# Altium schematic sheet BrewTempController.SchDoc
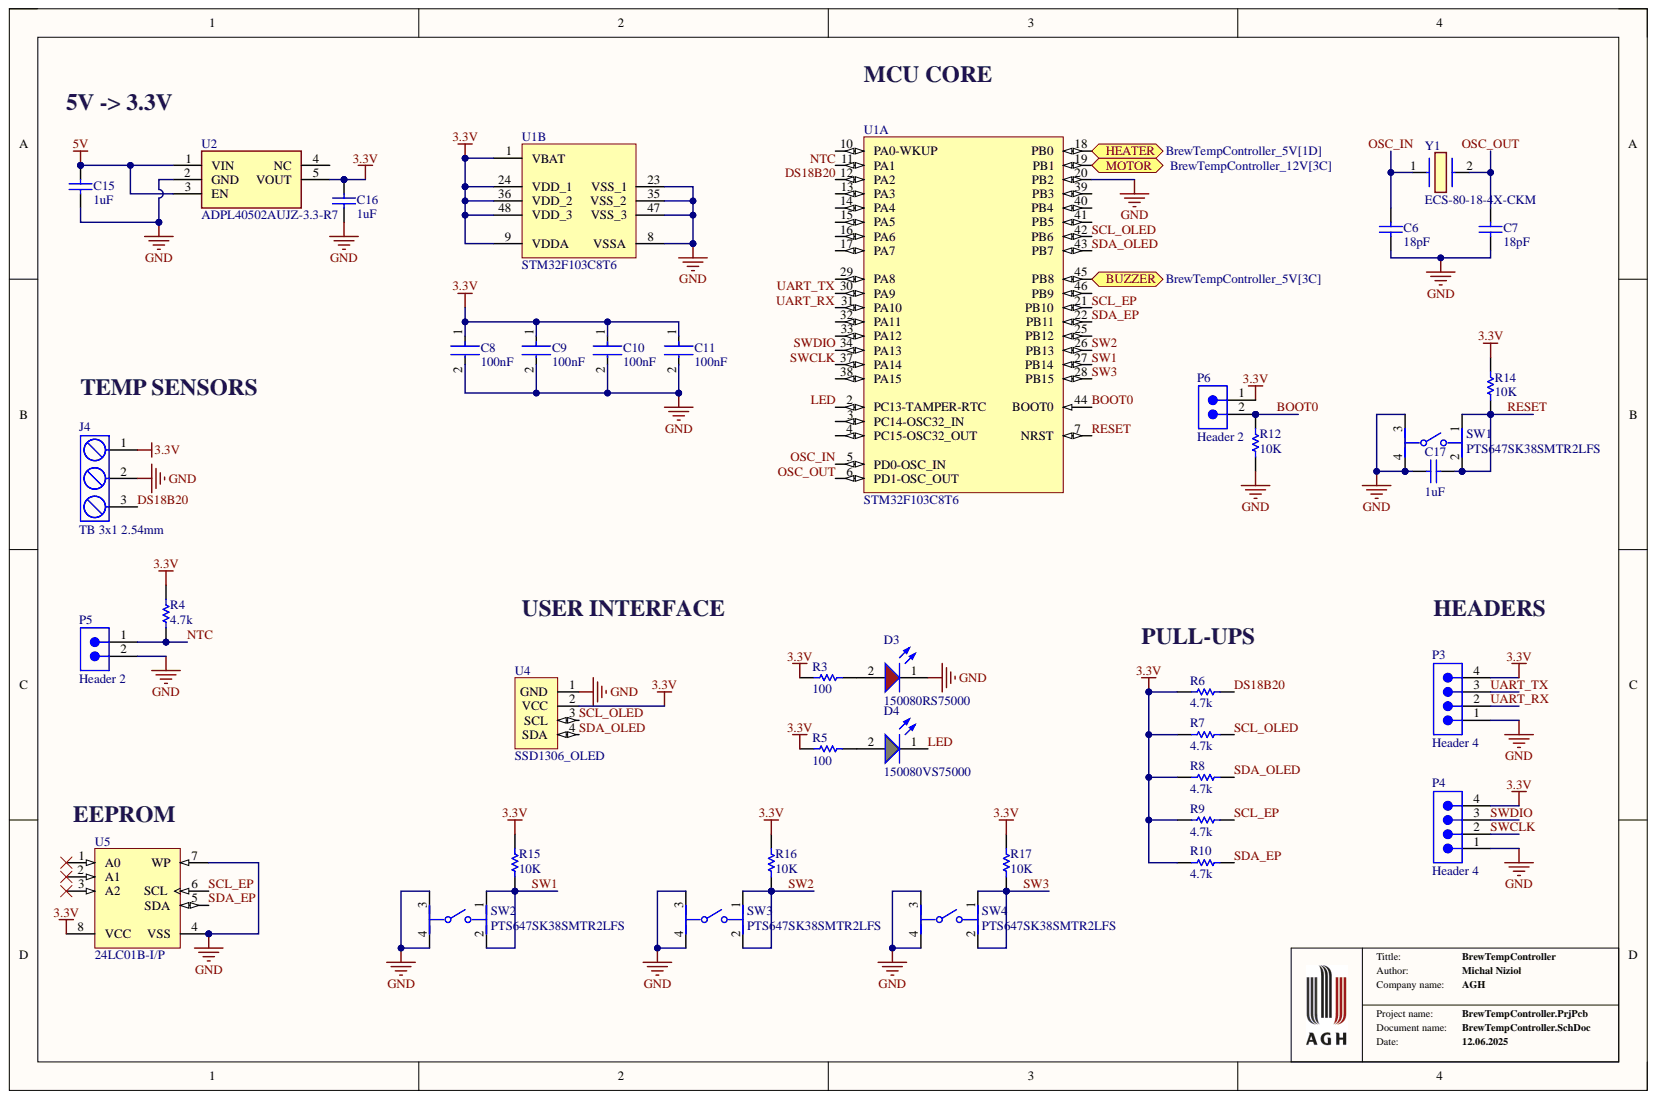
[diagram p0: BrewTempController.SchDoc]

## Parts
C6  18pF  [CAPC0805(2012)75_L]
C7  18pF  [CAPC0805(2012)75_L]
C8  100nF  [FP-0805-L_2_01_0_2-W_1_25-MFG]
C9  100nF  [FP-0805-L_2_01_0_2-W_1_25-MFG]
C10  100nF  [FP-0805-L_2_01_0_2-W_1_25-MFG]
C11  100nF  [FP-0805-L_2_01_0_2-W_1_25-MFG]
C15  1uF  [CAPC2013X140X50ML20T25]
C16  1uF  [CAPC2013X140X50ML20T25]
C17  1uF  [CAPC2013X140X50ML20T25]
D3  150080RS75000  [WL-SMCW_0805_150080xx75000]
D4  150080VS75000  [WL-SMCW_0805_150080xx75000]
J4  TB 3x1 2.54mm  [TB_3x1_2.54mm]
P3  Header 4  [61300411121]
P4  Header 4  [61300411121]
P5  Header 2  [SMTC-TSW-102-26-XX-S]
P6  Header 2  [SMTC-TSW-102-26-XX-S]
R3  100  [RESC2013X60X30LL20T20]
R4  4.7k  [RESC2013X60X30LL20T20]
R5  100  [RESC2013X60X30LL20T20]
R6  4.7k  [RESC2013X60X30LL20T20]
R7  4.7k  [RESC2013X60X30LL20T20]
R8  4.7k  [RESC2013X60X30LL20T20]
R9  4.7k  [RESC2013X60X30LL20T20]
R10  4.7k  [RESC2013X60X30LL20T20]
R12  10K  [RESC2013X60X30NL20T20]
R14  10K  [RESC2013X60X30NL20T20]
R15  10K  [RESC2013X60X30NL20T20]
R16  10K  [RESC2013X60X30NL20T20]
R17  10K  [RESC2013X60X30NL20T20]
SW1  PTS647SK38SMTR2LFS  [FP-PTS647SK38SMTR2LFS-MFG]
SW2  PTS647SK38SMTR2LFS  [FP-PTS647SK38SMTR2LFS-MFG]
SW3  PTS647SK38SMTR2LFS  [FP-PTS647SK38SMTR2LFS-MFG]
SW4  PTS647SK38SMTR2LFS  [FP-PTS647SK38SMTR2LFS-MFG]
U1  STM32F103C8T6  [STM-LQFP48_L]  (parts A,B)
U2  ADPL40502AUJZ-3.3-R7  [SOT95P280X100-5N]
U4  SSD1306_OLED  [SSD1306]
U5  24LC01B-I/P  [PDIP300-P8]
Y1  ECS-80-18-4X-CKM  [ECS80184XCKM]

## Ports
BUZZER, HEATER, MOTOR

## Nets
3.3V: C8.1 C9.1 C10.1 C11.1 C16.2 J4.1 P3.4 P4.4 P6.1 R3.1 R4.2 R5.1 R6.1 R7.1 R8.1 R9.1 R10.1 R14.2 R15.2 R16.2 R17.2 U1.1(VBAT) U1.9(VDDA) U1.24(VDD_1) U1.36(VDD_2) U1.48(VDD_3) U2.5(VOUT) U4.2(VCC) U5.8(VCC)
5V: C15.2 U2.1(VIN) U2.3(EN)
GND: C6.1 C7.1 C8.2 C9.2 C10.2 C11.2 C15.1 C16.1 C17.1 D3.1 J4.2 P3.1 P4.1 P5.2 R12.1 SW1.3 SW1.4 SW2.3 SW2.4 SW3.3 SW3.4 SW4.3 SW4.4 U1.8(VSSA) U1.20(PB2) U1.23(VSS_1) U1.35(VSS_2) U1.47(VSS_3) U2.2(GND) U4.1(GND) U5.4(VSS) U5.7(WP)
BOOT0: P6.2 R12.2 U1.44(BOOT0)
BUZZER: U1.45(PB8) ; port BUZZER
DS18B20: J4.3 R6.2 U1.12(PA2)
HEATER: U1.18(PB0) ; port HEATER
LED: D4.1 U1.2(PC13-TAMPER-RTC)
MOTOR: U1.19(PB1) ; port MOTOR
NTC: P5.1 R4.1 U1.11(PA1)
OSC_IN: C6.2 U1.5(PD0-OSC_IN) Y1.1
OSC_OUT: C7.2 U1.6(PD1-OSC_OUT) Y1.2
RESET: C17.2 R14.1 SW1.1 SW1.2 U1.7(NRST)
SCL_EP: R9.2 U1.21(PB10) U5.6(SCL)
SCL_OLED: R7.2 U1.42(PB6) U4.3(SCL)
SDA_EP: R10.2 U1.22(PB11) U5.5(SDA)
SDA_OLED: R8.2 U1.43(PB7) U4.4(SDA)
SW1: R15.1 SW2.1 SW2.2 U1.27(PB14)
SW2: R16.1 SW3.1 SW3.2 U1.26(PB13)
SW3: R17.1 SW4.1 SW4.2 U1.28(PB15)
SWCLK: P4.2 U1.37(PA14)
SWDIO: P4.3 U1.34(PA13)
UART_RX: P3.2 U1.31(PA10)
UART_TX: P3.3 U1.30(PA9)
NetD3_2: D3.2 R3.2
NetD4_2: D4.2 R5.2

Not connected: U1 3(PC14-OSC32_IN) 4(PC15-OSC32_OUT) 10(PA0-WKUP) 13(PA3) 14(PA4) 15(PA5) 16(PA6) 17(PA7) 25(PB12) 29(PA8) 32(PA11) 33(PA12) 38(PA15) 39(PB3) 40(PB4) 41(PB5) 46(PB9); U2 4(NC); U5 1(A0) 2(A1) 3(A2)

## Library data of the parts
C6 C7 = CMP-1036-01120-1: CAP 18pF 16V ±5% 0805 (2012 Metric) Thickness 0.75mm SMD; Case-EIA: 0805; Case-Metric: 2012; Tolerance: Â±5%; Component Kind: Standard; Library Name: Altium Content Vault; Max Thickness: 0.75 mm; Rated Voltage: 16 V; Technology: SMT; Value: 18pF
C8-C11 = CMP-2007-04725-2: Automotive Ceramic Capacitor, 0805, 100nF, 5%, X7R, 15%, 50V; Dissipation Factor: 2.5%; Capacitance: 100nF; Case Code (Imperial): 0805; Case Code (Metric): 2012; Case/Package: 0805; Component Kind: Standard; Construction: Flat; Contact Plating: Tin; Depth: 1.25mm; Dielectric: X7R; Height: 0.94mm; Lead Free: Lead Free; Length: 2.01mm; Library Name: Altium Content Vault; Max Operating Temperature: 125°C; Min Operating Temperature: -55°C; Mount: Surface Mount; Number of Pins: 2; Package Quantity: 4000; Packaging: Tape and Reel; Radiation Hardening: No; Ratings: AEC-Q200; RoHS Compliant: Yes; Series: -; Termination: SMD/SMT; Thickness: 0.037inch; Tolerance: 5%; Voltage Rating: 50V; Voltage Rating (DC): 50V; Width: 0.049inch
C15-C17 = CMP-1036-04744-2: Manufacturer: AVX Interconnect / Elco; Part Number: 0805YC105JAT2A; Component Kind: Standard; Library Name: Altium Content Vault
D3 = CMP-1426-00011-3: LED Uni-Color Red 630nm 2-Pin Chip 0805(2012Metric) T/R; Dominant Wavelength: 625nm; Forward Current: 100mA; Forward Voltage: 2V; Lens Style: Clear, Rectangular; Luminous Intensity: 150mcd; Max Junction Temperature (Tj): 95°C; Max Reverse Voltage (DC): 5V; Power Dissipation: 72mW; Test Current: 20mA; Viewing Angle: 140°; Case Code (Imperial): 0805; Case Code (Metric): 2012; Case/Package: 0805; Color: Red; Component Kind: Standard; Height: 700µm; Length: 2mm; Library Name: Altium Content Vault; Max Operating Temperature: 85°C; Min Operating Temperature: -40°C; Mount: Surface Mount; Packaging: Tape and Reel; Peak Wavelength: 630nm; REACH SVHC: Unknown; RoHS: Compliant; Wavelength: 625nm; Width: 1.25mm
D4 = CMP-1426-00009-6: WL-SMCW SMT Mono-Color Chip LED Waterclear; Color: Green; Dominant Wavelength: 570nm; Forward Current: 100mA; Forward Voltage: 2V; Lens Style: Clear, Rectangular; Luminous Intensity: 40mcd; Max Junction Temperature (Tj): 95°C; Max Reverse Voltage (DC): 5V; Peak Wavelength: 572nm; Power Dissipation: 72mW; Test Current: 20mA; Viewing Angle: 140°; Case Code (Imperial): 0805; Case Code (Metric): 2012; Case/Package: 0805; Component Kind: Standard; Height: 700µm; Length: 2mm; Library Name: Altium Content Vault; Max Operating Temperature: 85°C; Min Operating Temperature: -40°C; Mount: Surface Mount; Packaging: Tape and Reel; REACH SVHC: Unknown; Reverse Voltage: 5V; RoHS: Compliant; Wavelength: 570nm; Width: 1.25mm
J4 = TB_3x1_2.54mm: Terminal block (ARK connector) 2x1 2.54mm; Component Kind: Standard; Library Name: Schlib_AGH.SchLib
P3 P4 = CMP-1502-01064-3: 61300411121; NexarPartId: 32855522; Connector Type: Male Pin; Contact Resistance: 20mΩ; Current Rating: 3A; Gender: Male; Number of Contacts: 4; Operating Supply Voltage: 250V; Pitch: 2.54mm; Color: Black; Component Kind: Standard; Contact Material: Copper; Contact Mating Length: 5.9944mm; Contact Plating: Gold; Height: 8.89mm; Lead Free: Lead Free; Lead Pitch: 2.54mm; Library Name: Altium Content Vault; Max Operating Temperature: 105°C; Min Operating Temperature: -40°C; Mount: Through Hole; Number of Pins: 4; Number of Positions: 4; Number of Rows: 1; Orientation: Vertical; Packaging: Bulk; REACH SVHC: Unknown; RoHS: Compliant; Termination: Solder; Voltage Rating: 250V
P5 P6 = CMP-1024-00329-1: 0.025" SQ Post Header, Through-hole, Vertical, -55 to 125 degC, 2.54 mm Pitch, 2-Pin, Male, RoHS; Manufacturer: Samtec; PartNumber: TSW-102-26-F-S; Pitch: 2.54 mm; Component Kind: Standard; ComponentLink1Description: Manufacturer URL; ComponentLink1URL: http://www.samtec.com; ComponentLink2Description: Datasheet; ComponentLink2URL: http://www.samtec.com/documents/webfiles/pdf/TSW_TH.PDF; ComponentLink3Description: Package Specification; ComponentLink3URL: http://www.samtec.com/documents/webfiles/cpdf/TSW-XXX-XX-XXX-X-XX-XXX-MKT.pdf; DatasheetVersion: F-214; Library Name: Altium Content Vault; Mounting Technology: Through-hole; Orientation: Vertical; PackageDescription: .025[0.64] SQ. Post Terminal Strip Assembly; PackageReference: TSW-102-26-XX-S; PackageVersion: DM, 8/1988; RoHS: True; Temperature Range: -55 to 125 degC
R3 R5 = CMP-2001-04583-1: RK73B2ATTD201J; Manufacturer: KOA Speer; Component Kind: Standard; Library Name: Altium Content Vault; Part Number: RK73B2ATTD201J
R4 R6-R10 = CMP-2001-04577-1: RK73B2ATTD472J; Manufacturer: KOA Speer; Component Kind: Standard; Library Name: Altium Content Vault; Part Number: RK73B2ATTD472J
R12 R14-R17 = CMP-2001-04657-1: Manufacturer: KOA Speer; Part Number: RK73B2ATTD103J; Component Kind: Standard; Library Name: Altium Content Vault
SW1-SW4 = CMP-10040-000033-1: TACT 4.5 X 4.5, 3.8 MM H, 2.5N,; Actuator Type: Standard; Illumination Type: Non-Illuminated; Mechanical Life: 100000Cycles; Operating Force: 250gf; Ratings: IP40; Switch Function: Off-Mom; Switch Travel: 0.25mm; Throw Configuration: SPST; Component Kind: Standard; Library Name: Altium Content Vault; Max Operating Temperature: 70°C; Min Operating Temperature: -20°C; Mount: Surface Mount; Packaging: Tape and Reel; Termination: Gull Wing
U1 = CMP-0237-00215-3: ARM Cortex-M3 32-bit MCU, 64 KB Flash, 20 KB Internal RAM, 37 I/Os, 48-pin LQFP, -40 to 85 degC, Tray; A/D Converter: 2x12-bit (10 Channel); FLASH Size (Prog) (kB): 64; I/Os (High Current): 37; Internal RAM Size (kB): 20; Operating Frequency(F) (Processor speed) (MHz): 72; Supply Voltage(Vcc) min (V): 2; Component Kind: Standard; ComponentLink1Description: Manufacturer URL; ComponentLink1URL: http://www.st.com/web/en/home.html; ComponentLink2Description: Datasheet; ComponentLink2URL: http://www.st.com/st-web-ui/static/active/en/resource/technical/document/datasheet/CD00161566.pdf; ComponentLink3Description: Package Specification; ComponentLink3URL: http://www.st.com/st-web-ui/static/active/en/resource/technical/document/datasheet/DM00058181.pdf; Core: ARM Cortex-M3; DatasheetVersion: Rev. 16; Library Name: Altium Content Vault; Mounting Technology: Surface Mount; PackageDescription: 48-Pin Low Profile Quad Flat Package, 7 x 7 mm Body, 0.5 mm Pitch; PackageReference: LQFP48; PackageVersion: Rev. 6, 06/2013; Packing: Tray; PartNumber: STM32F103C8T6
U2 = ADPL40502AUJZ-3.3-R7: Integrated Circuit; Manufacturer_Name: Analog Devices; Component Kind: Standard; Datasheet Link: https://www.analog.com/ADPL40502/datasheet; Height: 1mm; Manufacturer_Part_Number: ADPL40502AUJZ-3.3-R7; Mouser Part Number: 584-ADPL40502AUJZ33R; Mouser Price/Stock: https://www.mouser.co.uk/ProductDetail/Analog-Devices/ADPL40502AUJZ-3.3-R7?qs=jcD%2FCkGBYeNAk1f%2F%2FzNShQ%3D%3D
U4 = SSD1306: OLED 0.96in; Component Kind: Standard; Library Name: Schlib_AGH.SchLib
U5 = CMP-0159-00113-1: 1Kbit, 400kHz, 2.5V, I2C Serial EEPROM, 8-Pin PDIP, Industrial Temperature; Code_JEDEC: MS-001; Component Kind: Standard; DatasheetVersion: revJ, Jan-2009; Library Name: Altium Content Vault; PackageDescription: 8-Lead Plastic Dual In-Line (P) - 300 mil Body [PDIP]; PackageReference: PDIP300-P8; PackageVersion: revBB, Aug-2009
Y1 = ECS-80-18-4X-CKM: Crystal or Oscillator; Manufacturer_Name: ECS; Arrow Part Number: ECS-80-18-4X-CKM; Arrow Price/Stock: https://www.arrow.com/en/products/ecs-80-18-4x-ckm/ecs-international?region=nac; Component Kind: Standard; Datasheet Link: https://www.mouser.de/datasheet/2/122/hc-49usx-791.pdf; Height: 3.5mm; Manufacturer_Part_Number: ECS-80-18-4X-CKM; Mouser Part Number: 520-ECS-80-18-4X-CKM; Mouser Price/Stock: https://www.mouser.co.uk/ProductDetail/ECS/ECS-80-18-4X-CKM?qs=7MVldsJ5UazWVU6%2F43NmVA%3D%3D
